# Altium schematic sheet Heater.SchDoc(Heater)
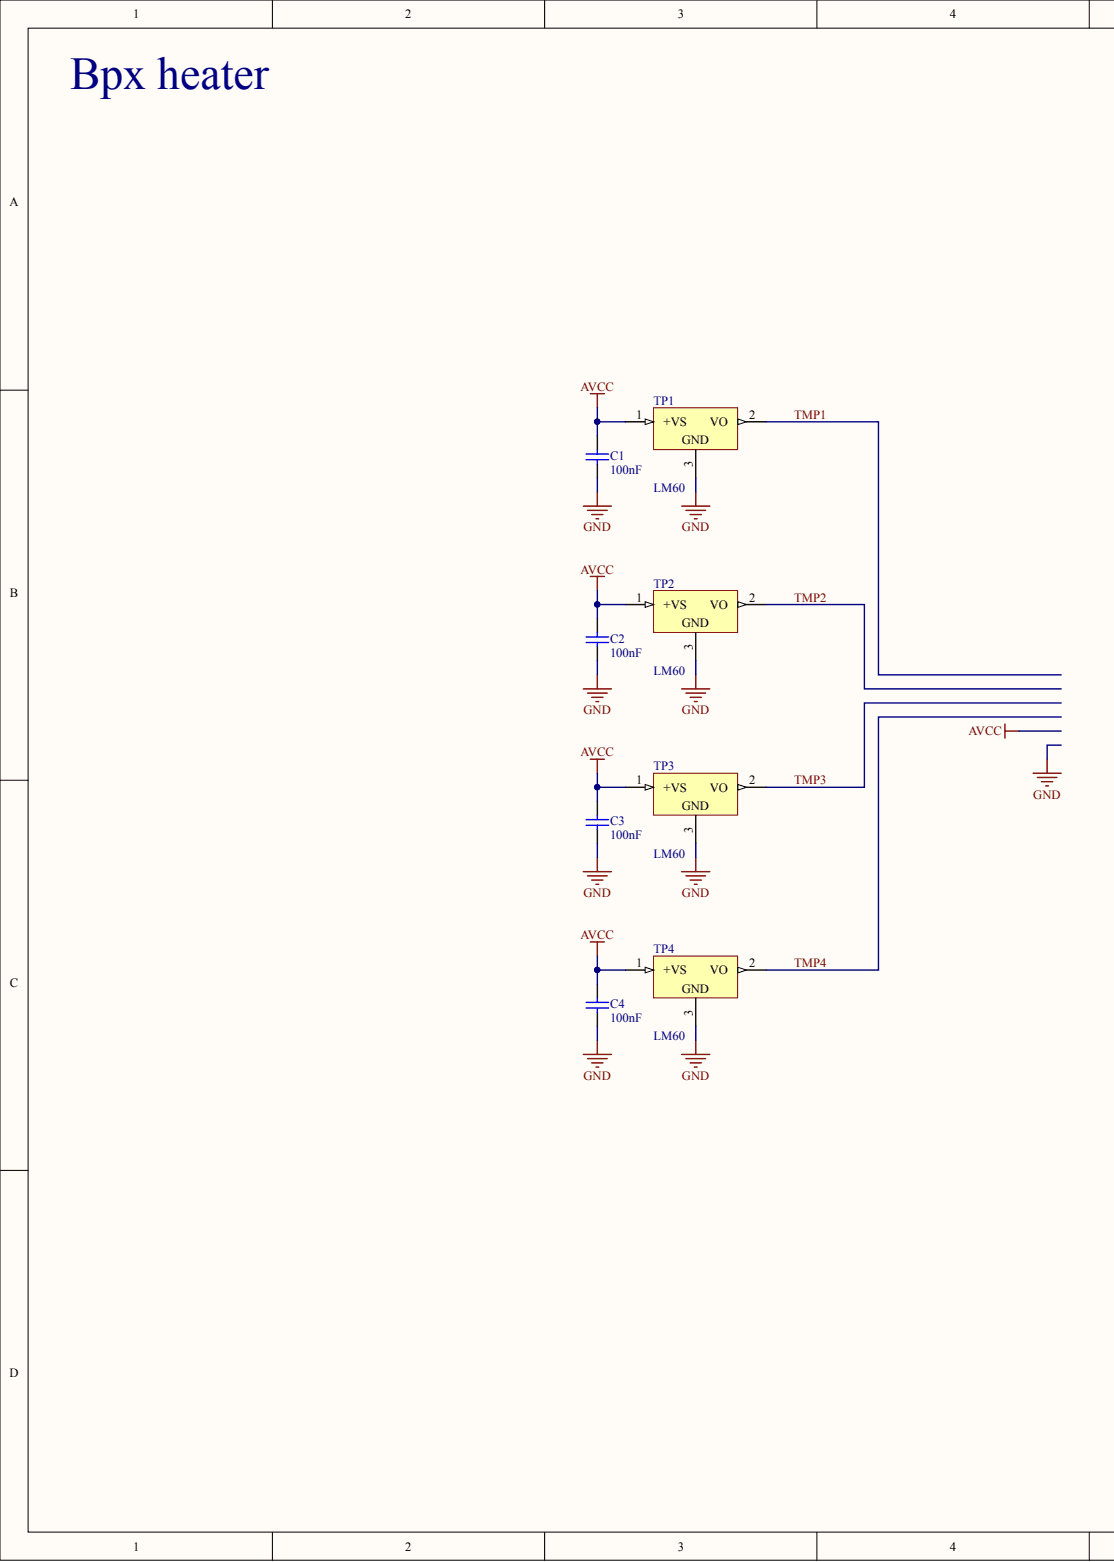
[diagram p0: Heater.SchDoc, left]
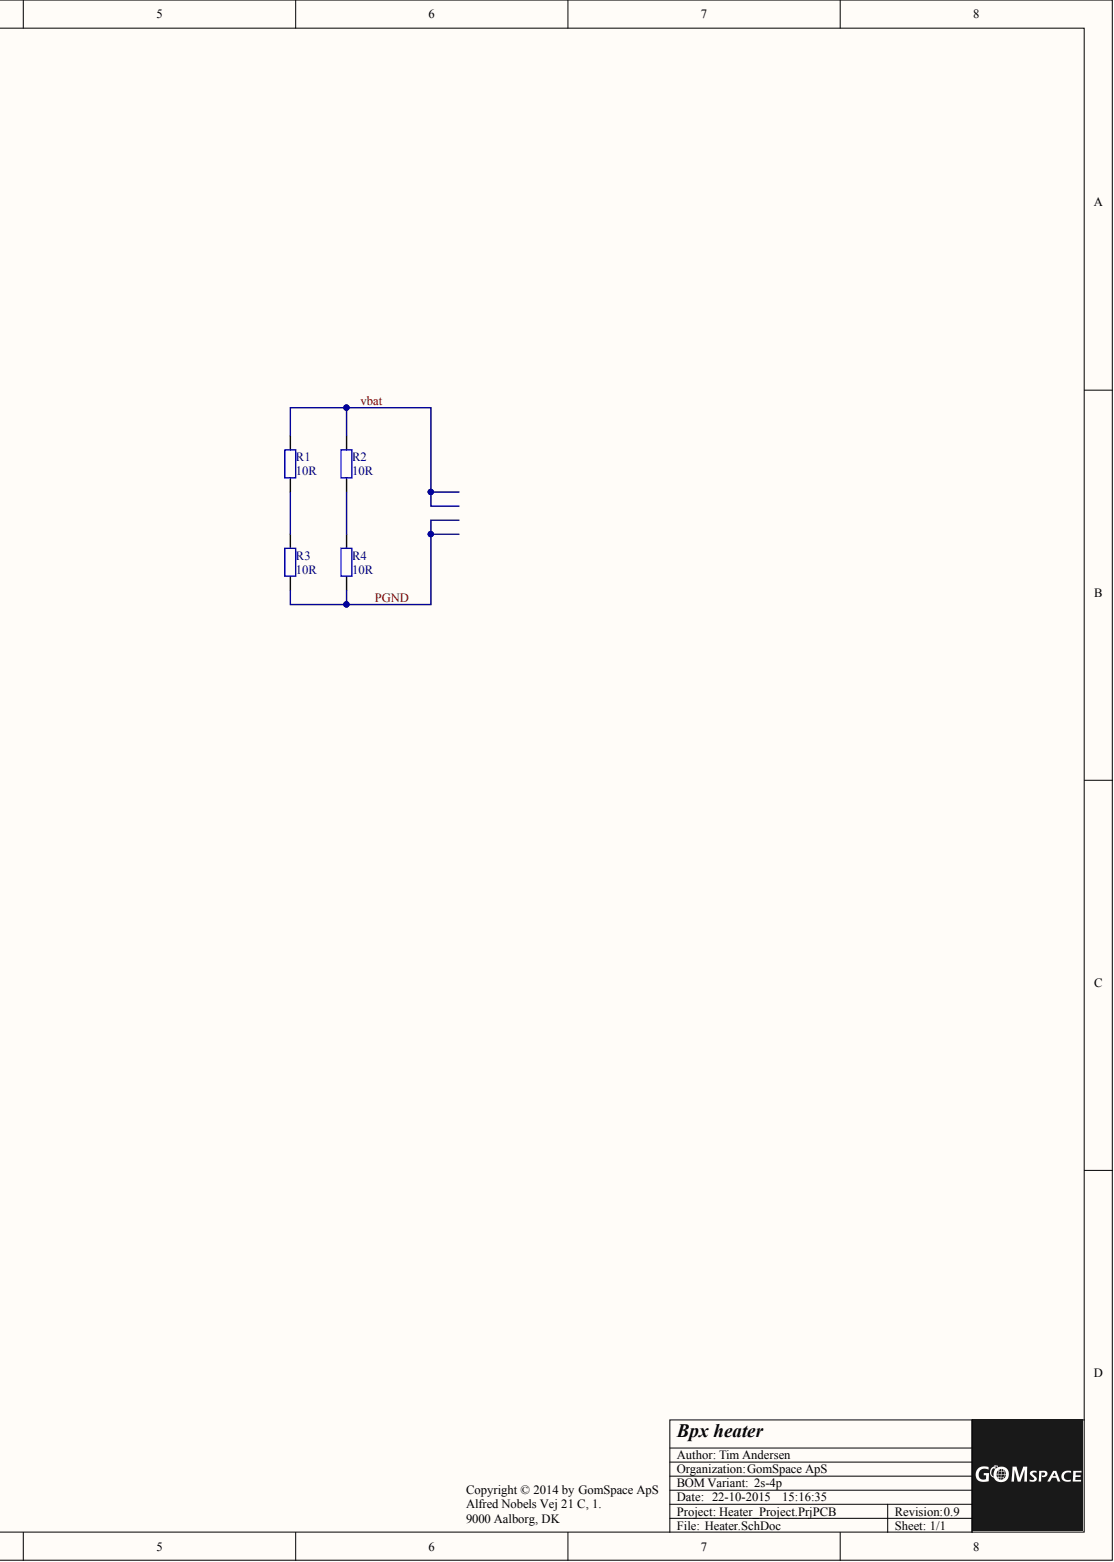
[diagram p1: Heater.SchDoc, right]

## Parts
C1  100nF  [CAPC0603(1608)_N]
C2  100nF  [CAPC0603(1608)_N]
C3  100nF  [CAPC0603(1608)_N]
C4  100nF  [CAPC0603(1608)_N]
R1  150R  [AXIAL-0.7]  (value not drawn)
R1  40R  [AXIAL-0.7]  (value not drawn)
R1  10R  [AXIAL-0.7]
R2  150R  [AXIAL-0.7]  (value not drawn)
R2  40R  [AXIAL-0.7]  (value not drawn)
R2  10R  [AXIAL-0.7]
R3  150R  [AXIAL-0.7]  (value not drawn)
R3  40R  [AXIAL-0.7]  (value not drawn)
R3  10R  [AXIAL-0.7]
R4  150R  [AXIAL-0.7]  (value not drawn)
R4  40R  [AXIAL-0.7]  (value not drawn)
R4  10R  [AXIAL-0.7]
TP1  LM60  [SOT-23-TT3_L]
TP2  LM60  [SOT-23-TT3_L]
TP3  LM60  [SOT-23-TT3_L]
TP4  LM60  [SOT-23-TT3_L]

## Nets
AVCC: C1.1 C2.1 C3.1 C4.1 TP1.1 TP2.1 TP3.1 TP4.1
GND: C1.2 C2.2 C3.2 C4.2 TP1.3 TP2.3 TP3.3 TP4.3
PGND: R3.1 R4.1
TMP1: TP1.2
TMP2: TP2.2
TMP3: TP3.2
TMP4: TP4.2
VBAT: R1.2 R2.2
NetR1_1: R1.1 R3.2
NetR2_1: R2.1 R4.2

## Library data of the parts
C1-C4 = 10000014: CAP CER 0.1UF 50V 10% X7R 0603; Manufacturer: KEMET; Manufacturer number: C0603C104K5RACTU; Part_Type: Capacitor; Database Table Name: Capacitors$; Hight: 0.87mm; Component Kind: Standard; Datasheet: http://capacitoredge.kemet.com/capedge2/intellispec.jsp?pn=C0603C104K5RACTU&displayPN=C0603C104K5RACTU; Package: 0603 (1608 Metric); Part Number: 10000014; Pin Count: 2; ROHS: YES; Supplier 1: Digi-Key; Supplier 2: Farnell; Supplier 3: Mouser; Supplier 4: Gomspace ApS; Supplier Part Number 1: 399-5089-1-ND; Supplier Part Number 2: 1288255; Supplier Part Number 3: 80-C0603C104K5R; Supplier Part Number 4: 589; Temperature: -55°C ~ 125°C; Temperature Coefficient: X7R; Tolerance: 10%; Value: 100nF; Voltage: 50V; Library Name: GomSpace_Database_Libs.DbLib
R1-R4 = 46030503: RES 150 OHM 3W 5% AXIAL; Manufacturer: Ohmite; Manufacturer number: 23J150E; Part_Type: Resistor; Database Table Name: Resistors$; Temperature Coefficient: ±30ppm/°C; Component Kind: Standard; Datasheet: http://www.ohmite.com/cat/res_20.pdf; Features: Flame Retardant Coating, Safety; Hight: -; Package: Axial; Part Number: 46030503; Power: 3W; ROHS: YES; Supplier 1: Digi-key; Supplier Part Number 1: 23J150E-ND; Tolerance: 5%; Value: 150R; Library Name: GomSpace_Database_Libs.DbLib
R1-R4 = 46030502: RES 40 OHM 3W 5% AXIAL; Manufacturer: Ohmite; Manufacturer number: 23J40RE; Part_Type: Resistor; Database Table Name: Resistors$; Temperature Coefficient: ±30ppm/°C; Component Kind: Standard; Datasheet: http://www.ohmite.com/cat/res_20.pdf; Features: Flame Retardant Coating, Safety; Hight: -; Package: Axial; Part Number: 46030502; Power: 3W; ROHS: YES; Supplier 1: Digi-key; Supplier Part Number 1: 23J40RE-ND; Tolerance: 5%; Value: 40R; Library Name: GomSpace_Database_Libs.DbLib
R1-R4 = 46030501: RES 10 OHM 3W 5% AXIAL; Manufacturer: Ohmite; Manufacturer number: 23J10RE; Part_Type: Resistor; Database Table Name: Resistors$; Temperature Coefficient: ±30ppm/°C; Component Kind: Standard; Datasheet: http://www.ohmite.com/cat/res_20.pdf; Features: Flame Retardant Coating, Safety; Hight: -; Package: Axial; Part Number: 46030501; Power: 3W; ROHS: YES; Supplier 1: Digi-key; Supplier Part Number 1: 23J10RE-ND; Tolerance: 5%; Value: 10R; Library Name: GomSpace_Database_Libs.DbLib
TP1-TP4 = 60000014: IC TEMPERATURE SENSOR SOT23-3; Manufacturer: Texas Instruments; Manufacturer number: LM60CIM3; Database Table Name: IC$; Hight: 1.12mm; Voltage: 3.3V; Component Kind: Standard; Datasheet: http://www.ti.com/lit/ds/symlink/lm60.pdf; Package: SOT-23-3; Part_Type: IC; Part Number: 60000014; Pin Count: 3; ROHS: YES; Supplier 1: Digi-Key; Supplier 4: Gomspace ApS; Supplier Part Number 1: LM60CIM3CT-ND; Supplier Part Number 4: 533; Temperature: -40°C ~ 125°C; Value: LM60; Library Name: GomSpace_Database_Libs.DbLib
